# Altium schematic sheet tree.SchDoc
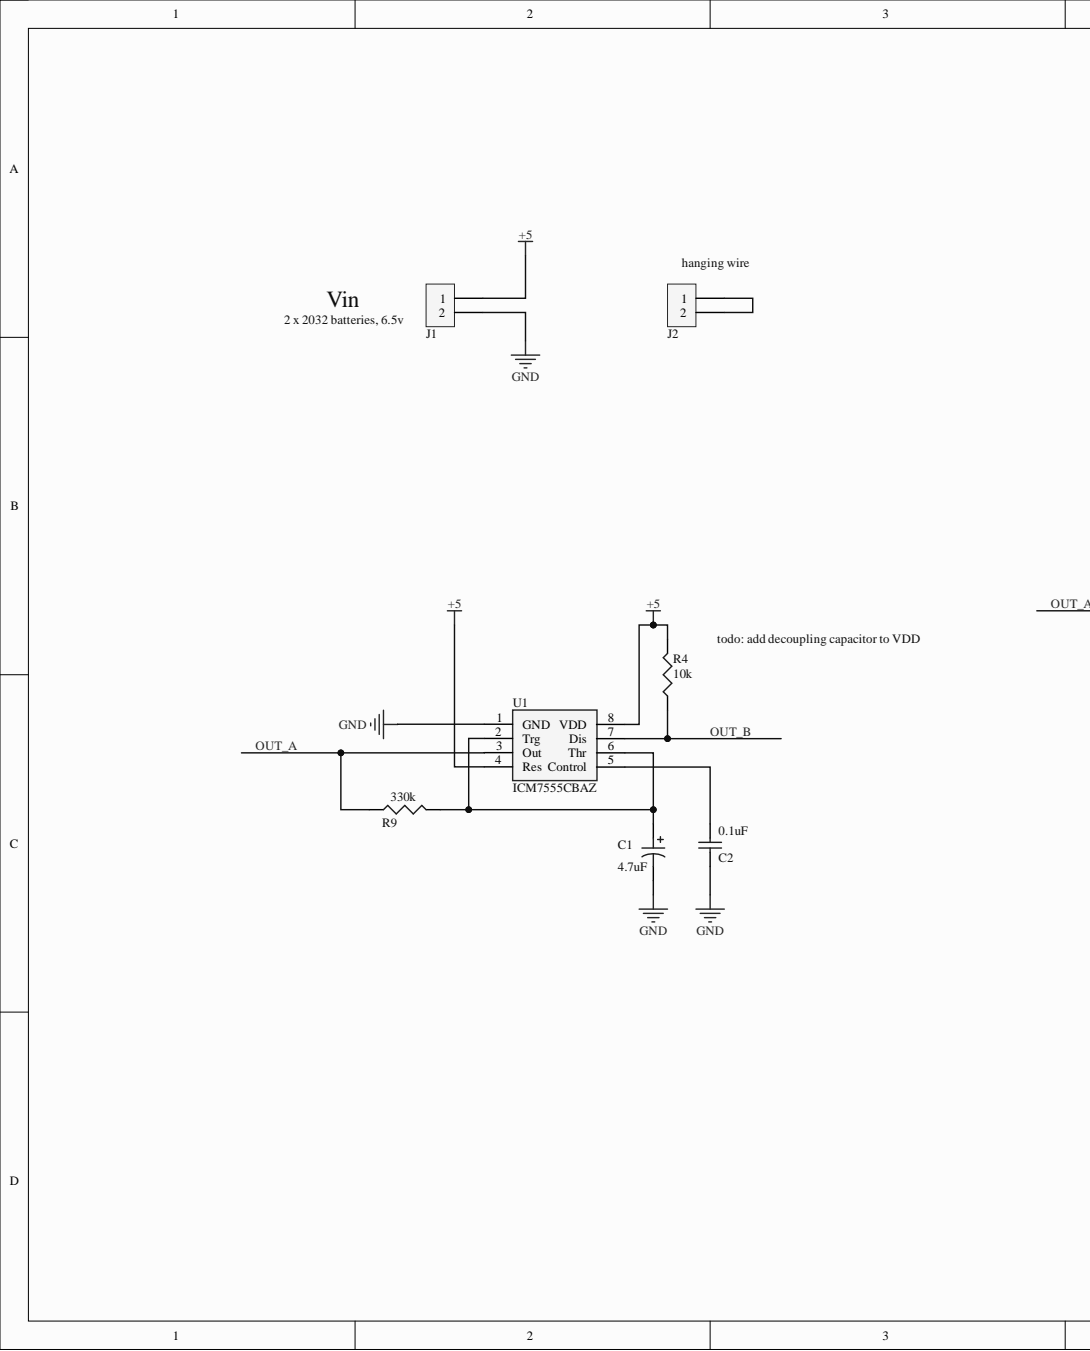
[diagram p0: tree.SchDoc, left]
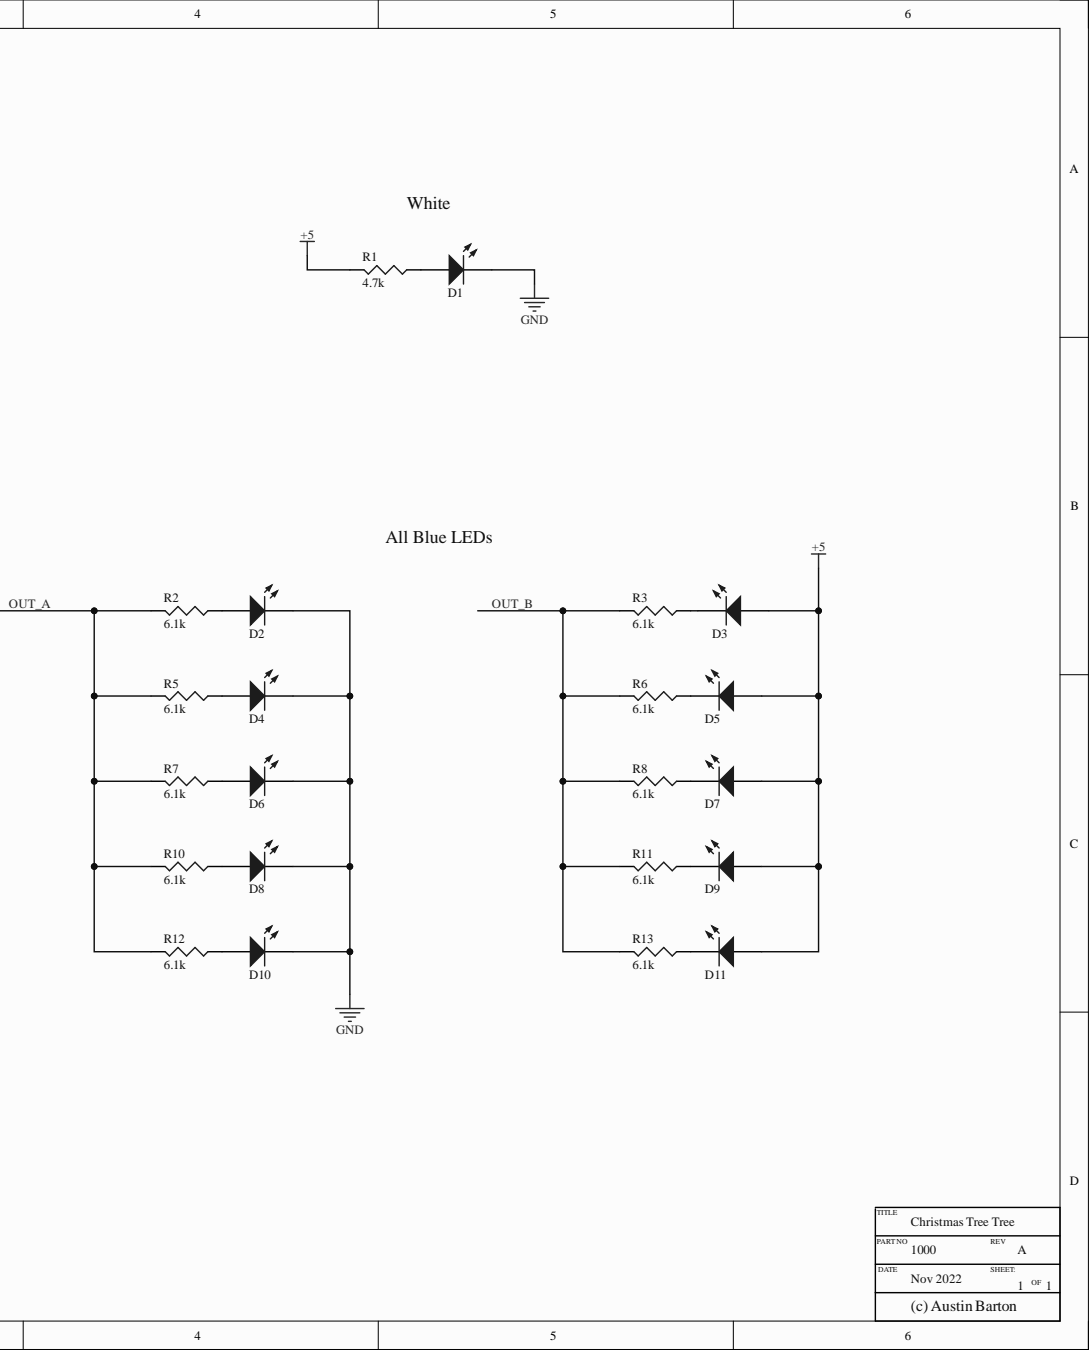
[diagram p1: tree.SchDoc, right]

## Parts
C1  4.7uF  [th_cap]
C2  0.1uF  [0805 (2012 Metric)]
D1  APT2012SURCK  [LED_0805_A_K]  (value not drawn)
D2  APT2012SURCK  [LED_0805_A_K]  (value not drawn)
D3  APT2012SURCK  [LED_0805_A_K]  (value not drawn)
D4  APT2012SURCK  [LED_0805_A_K]  (value not drawn)
D5  APT2012SURCK  [LED_0805_A_K]  (value not drawn)
D6  APT2012SURCK  [LED_0805_A_K]  (value not drawn)
D7  APT2012SURCK  [LED_0805_A_K]  (value not drawn)
D8  APT2012SURCK  [LED_0805_A_K]  (value not drawn)
D9  APT2012SURCK  [LED_0805_A_K]  (value not drawn)
D10  APT2012SURCK  [LED_0805_A_K]  (value not drawn)
D11  APT2012SURCK  [LED_0805_A_K]  (value not drawn)
J1  (no value)  [th_cap]
J2  (no value)  [th_cap]
R1  4.7k  [0805 (2012 Metric)]
R2  6.1k  [0805 (2012 Metric)]
R3  6.1k  [0805 (2012 Metric)]
R4  10k  [0805 (2012 Metric)]
R5  6.1k  [0805 (2012 Metric)]
R6  6.1k  [0805 (2012 Metric)]
R7  6.1k  [0805 (2012 Metric)]
R8  6.1k  [0805 (2012 Metric)]
R9  330k  [0805 (2012 Metric)]
R10  6.1k  [0805 (2012 Metric)]
R11  6.1k  [0805 (2012 Metric)]
R12  6.1k  [0805 (2012 Metric)]
R13  6.1k  [0805 (2012 Metric)]
U1  ICM7555CBAZ  [ICM7555CBAZ]

## Nets
+5: D3.1 D5.1 D7.1 D9.1 D11.1 J1.1 R1.2 R4.2 U1.4(Res) U1.8(VDD)
GND: C1.2 C2.1 D1.2 D2.2 D4.2 D6.2 D8.2 D10.2 J1.2 U1.1(GND)
OUT_A: R2.2 R5.2 R7.2 R9.1 R10.2 R12.2 U1.3(Out)
OUT_B: R3.2 R4.1 R6.2 R8.2 R11.2 R13.2 U1.7(Dis)
NetC1_1: C1.1 R9.2 U1.2(Trg) U1.6(Thr)
NetC2_2: C2.2 U1.5(Control)
NetD1_1: D1.1 R1.1
NetD2_1: D2.1 R2.1
NetD3_2: D3.2 R3.1
NetD4_1: D4.1 R5.1
NetD5_2: D5.2 R6.1
NetD6_1: D6.1 R7.1
NetD7_2: D7.2 R8.1
NetD8_1: D8.1 R10.1
NetD9_2: D9.2 R11.1
NetD10_1: D10.1 R12.1
NetD11_2: D11.2 R13.1
NetJ2_1: J2.1 J2.2

## Library data of the parts
C1 = th_cap: SMT Polarised Capacitor; Component Kind: Standard; Library Name: th_cap.SCHLIB
C2 = C0805C180J5GACTU: 0.1pF 50V Ceramic Capacitor C0G, NP0 0805 (2012 Metric); Manufacturer: Kemet; Database Table Name: Capacitor_SchLib; Tolerance: ±5%; Value: 18pF; Category: Capacitors; Component Kind: Standard; ComponentLink1Description: Datasheet; ComponentLink1URL: http://www.kemet.com/Lists/ProductCatalog/Attachments/46/KEM_C1003_C0G_SMD.pdf; Dielectric: C0G, NP0; Library Name: database.SVNDBLib; Manufacturer Part Number: C0805C180J5GACTU; Operating Temperature: -55°C ~ 125°C; Package: 0805 (2012 Metric); Part Number: C0805C180J5GACTU; Price(qty 1000): 0.02040; RoHS: RoHS Compliant; Supplier 1: Digi-Key; Supplier Part Number 1: 399-1112-1-ND; Voltage: 50V
D1-D11 = APT2012SURCK: LED RED CLEAR 0805 SMD; Manufacturer: Kingbright; Current - Test: 20mA; Database Table Name: LED_SchLib; Lens Color: Colorless; Millicandela Rating: 80mcd; Vf: 1.95V; Viewing Angle: 120°; Category: LED; Color: Red; Component Kind: Standard; ComponentLink1Description: Datasheet; ComponentLink1URL: http://www.kingbrightusa.com/images/catalog/SPEC/APT2012SURCK.pdf; Height (Max): 0.75mm; Lens Transparency: Clear; Library Name: database.SVNDBLib; Manufacturer Part Number: APT2012SURCK; Mounting Type: Surface Mount; Package: 0805 (2012 Metric); Part Number: APT2012SURCK; Price(qty 1000): 0.08349; RoHS: Lead free / RoHS Compliant; Supplier 1: Digi-Key; Supplier Part Number 1: 754-1133-1-ND
J1 J2 = HDR1x2: 2 PIN HEADER TIN; Component Kind: Standard; Library Name: hdr1x2.Schlib
R1-R13 = RC0805FR-07120RL: RES SMD 1% 1/8W 0805; Manufacturer: Yageo; Database Table Name: Resistor_SchLib; Power: 0.125W, 1/8W; Temperature Coefficient: ±100ppm/°C; Value: 120; Category: Resistors; Component Kind: Standard; ComponentLink1Description: http://www.yageo.com/documents/recent/PYu-RC_Group_51_RoHS_L_04.pdf; ComponentLink1URL: http://www.yageo.com/documents/recent/PYu-RC_Group_51_RoHS_L_04.pdf; Composition: Thick Film; Features: Moisture Resistant; Height: 0.024" (0.60mm); Library Name: database.SVNDBLib; Manufacturer Part Number: RC0805FR-07120RL; Operating Temperature: -55°C ~ 155°C; Package: 0805 (2012 Metric); Part Number: RC0805FR-07120RL; Price(qty 1000): 0.00379; RoHS: RoHS Compliant; Size / Dimension: 0.079" L x 0.049" W (2.00mm x 1.25mm); Supplier 1: Digi-Key; Supplier Part Number 1: 311-120CRCT-ND; Tolerance: ±1%
U1 = ICM7555CBAZ: Timers & Support Products GEN PURPSE CMOS TIMR COM; MF: On Shore; MP: USB-A1VSB6; Availability: Unavailable; Component Kind: Standard; Library Name: ICM7555CBAZ.SchLib; Package: None; Price: None; SnapEDA_Link: https://www.snapeda.com/parts/USB-A1VSB6/On%20Shore%20Technology%20Inc./view-part/481020/?ref=snap
